# Altium schematic sheet Sch11 - Analog Inputs 1.SchDoc("Sch11 - Analog Inputs 1")
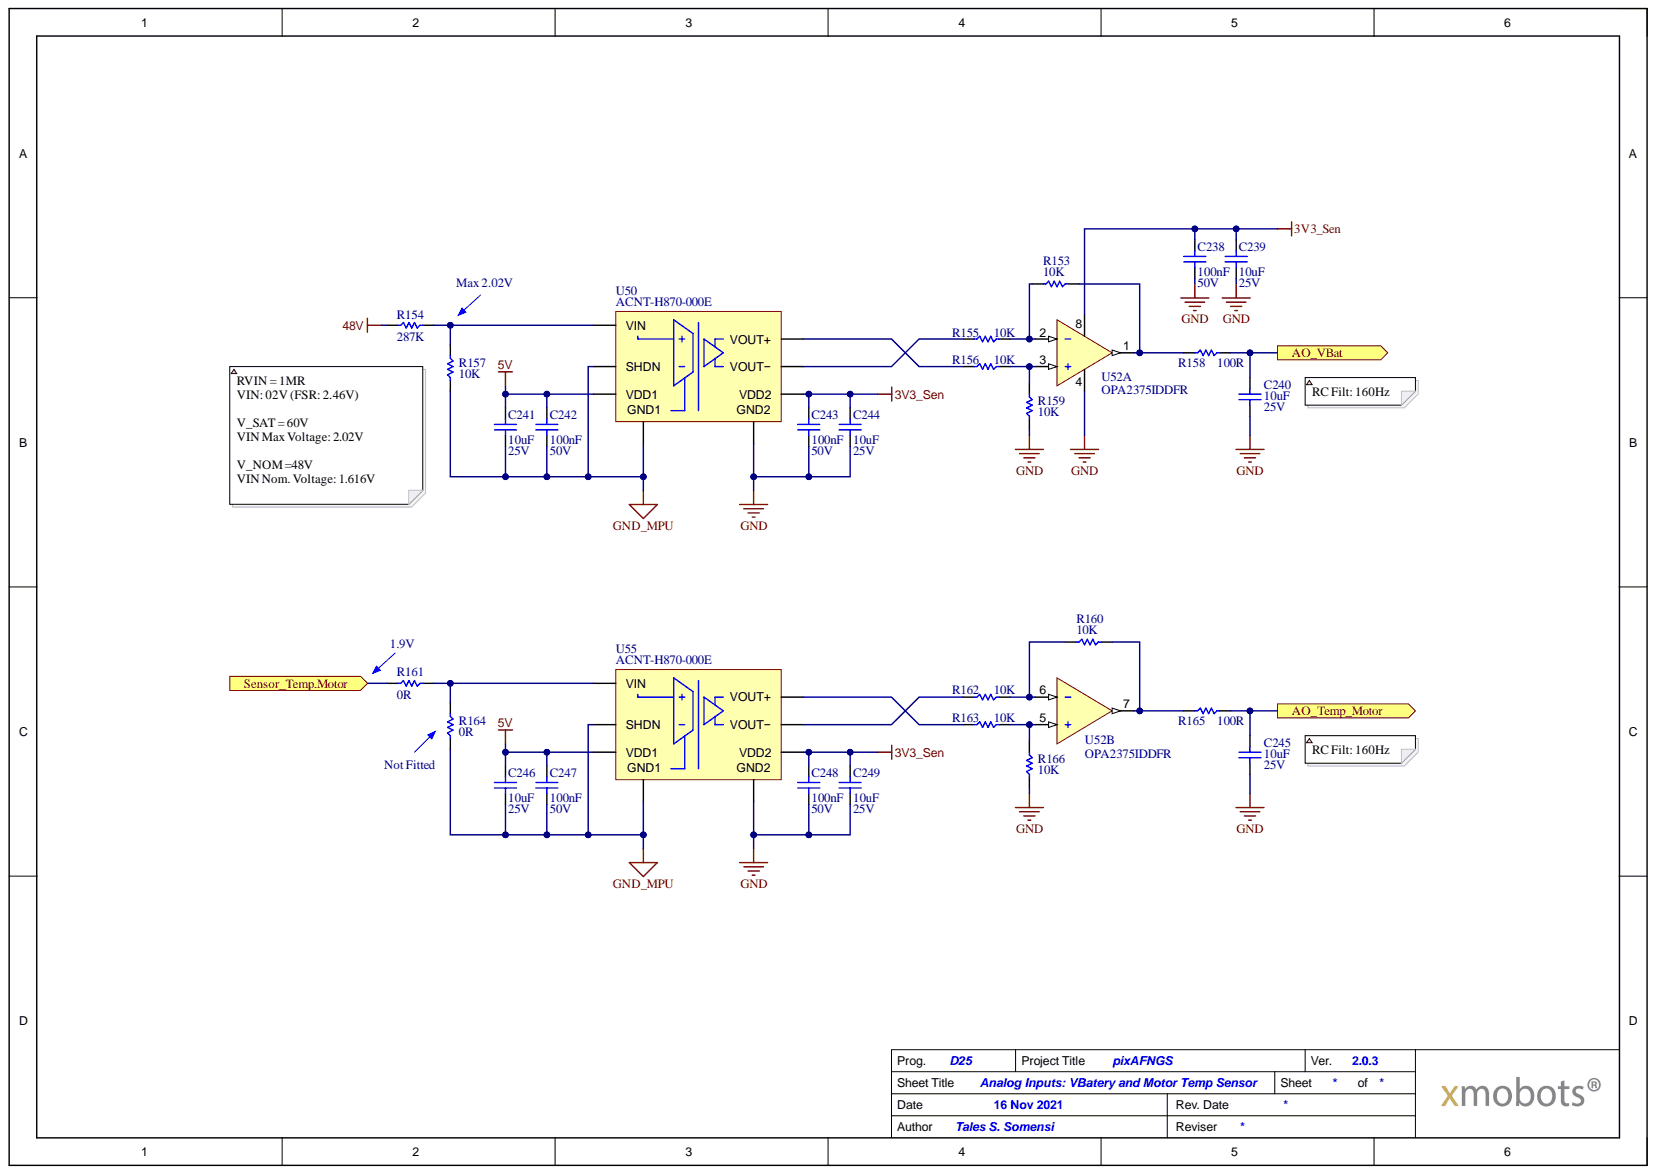
[diagram p0: Sch11 - Analog Inputs 1.SchDoc]

## Parts
C238  Capacitance: 100nF  Voltage: 50V  [0603]  (hidden comment: CL10B104MB8NNNC)
C239  Capacitance: 10uF  Voltage: 25V  [0603]  (hidden comment: GRM188R61E106KA73J)
C240  Capacitance: 10uF  Voltage: 25V  [0603]  (hidden comment: GRM188R61E106KA73J)
C241  Capacitance: 10uF  Voltage: 25V  [0603]  (hidden comment: GRM188R61E106KA73J)
C242  Capacitance: 100nF  Voltage: 50V  [0603]  (hidden comment: CL10B104MB8NNNC)
C243  Capacitance: 100nF  Voltage: 50V  [0603]  (hidden comment: CL10B104MB8NNNC)
C244  Capacitance: 10uF  Voltage: 25V  [0603]  (hidden comment: GRM188R61E106KA73J)
C245  Capacitance: 10uF  Voltage: 25V  [0603]  (hidden comment: GRM188R61E106KA73J)
C246  Capacitance: 10uF  Voltage: 25V  [0603]  (hidden comment: GRM188R61E106KA73J)
C247  Capacitance: 100nF  Voltage: 50V  [0603]  (hidden comment: CL10B104MB8NNNC)
C248  Capacitance: 100nF  Voltage: 50V  [0603]  (hidden comment: CL10B104MB8NNNC)
C249  Capacitance: 10uF  Voltage: 25V  [0603]  (hidden comment: GRM188R61E106KA73J)
R153  Resistance: 10K  [Res_0603]  (hidden comment: RMCF0603FT10K0)
R154  Resistance: 287K  [Res_0603]  (hidden comment: RMCF0603FT287K)
R155  Resistance: 10K  [Res_0603]  (hidden comment: RMCF0603FT10K0)
R156  Resistance: 10K  [Res_0603]  (hidden comment: RMCF0603FT10K0)
R157  Resistance: 10K  [Res_0603]  (hidden comment: RMCF0603FT10K0)
R158  Resistance: 100R  [Res_0603]  (hidden comment: RMCF0603FT100R)
R159  Resistance: 10K  [Res_0603]  (hidden comment: RMCF0603FT10K0)
R160  Resistance: 10K  [Res_0603]  (hidden comment: RMCF0603FT10K0)
R161  Resistance: 0R  [Res_0603]  (hidden comment: RMCF0603ZT0R00)
R162  Resistance: 10K  [Res_0603]  (hidden comment: RMCF0603FT10K0)
R163  Resistance: 10K  [Res_0603]  (hidden comment: RMCF0603FT10K0)
R164  Resistance: 0R  [Res_0603]  (hidden comment: RMCF0603ZT0R00)
R165  Resistance: 100R  [Res_0603]  (hidden comment: RMCF0603FT100R)
R166  Resistance: 10K  [Res_0603]  (hidden comment: RMCF0603FT10K0)
U50  ACNT-H870-000E  [stretched_SO-8]
U52  OPA2375IDDFR  [SOT-23-8]  (parts A,B)
U55  ACNT-H870-000E  [stretched_SO-8]

## Ports
AO_Temp_Motor, AO_VBat, Sensor_Temp.Motor

## Nets
3V3_Sen: C238.2 C239.2 C243.2 C244.2 C248.2 C249.2 U50.8(VDD2) U52.8 U55.8(VDD2)
5V: C241.2 C242.2 C246.2 C247.2 U50.1(VDD1) U55.1(VDD1)
48V: R154.2
GND: C238.1 C239.1 C240.1 C243.1 C244.1 C245.1 C248.1 C249.1 R159.1 R166.1 U50.5 U52.4 U55.5
GND_MPU: C241.1 C242.1 C246.1 C247.1 R157.1 R164.1 U50.3(SHDN) U50.4 U55.3(SHDN) U55.4
AO_Temp_Motor: C245.2 R165.2 ; port AO_Temp_Motor
AO_VBat: C240.2 R158.2 ; port AO_VBat
Sensor_Temp.Motor: R161.1 ; port Sensor_Temp.Motor
NetR153_1: R153.1 R158.1 U52.1
NetR153_2: R153.2 R155.1 U52.2
NetR154_1: R154.1 R157.2 U50.2(VIN)
NetR155_2: R155.2 U50.6(VOUT−)
NetR156_1: R156.1 R159.2 U52.3
NetR156_2: R156.2 U50.7(VOUT+)
NetR160_1: R160.1 R165.1 U52.7
NetR160_2: R160.2 R162.1 U52.6
NetR161_2: R161.2 R164.2 U55.2(VIN)
NetR162_2: R162.2 U55.6(VOUT−)
NetR163_1: R163.1 R166.2 U52.5
NetR163_2: R163.2 U55.7(VOUT+)

## Library data of the parts
C238 C242 C243 C247 C248 = C-100nF-50V-X7R-0603: Capacitor 100nF 50V X7R 0603; Component Kind: Standard; Part Number: CL10B104MB8NNNC; Library Name: xmobots_-_comp_library.IntLib
C239-C241 C244-C246 C249 = C-CER-10uF-25V-X5R-0603: Capacitor 10uF 25V X5R 0603; Component Kind: Standard; Part Number: GRM188R61E106KA73J; Library Name: xmobots_-_comp_library.IntLib
R153 R155-R157 R159 R160 R162 R163 R166 = R-10K-1/10W-1%-0603: Resistor 10k ohms 1/10W 1% 0603; Component Kind: Standard; Part Number: RMCF0603FT10K0; Power: 1/10W; Tolerance: ±1%; Library Name: xmobots_-_comp_library.IntLib
R154 = R-287K-1/10W-1%-0603: Resistor 287k ohms 1/10W 1% 0603; Component Kind: Standard; Library Name: WarLib.IntLib; Part Number: RMCF0603FT287K; Power: 1/10W; Tolerance: ±1%
R158 R165 = R-100R-1/10W-1%-0603: Resistor 100 ohms 1/10W 1% 0603; Component Kind: Standard; Part Number: RMCF0603FT100R; Power: 1/10W; Tolerance: ±1%; Library Name: xmobots_-_comp_library.IntLib
R161 R164 = R-0R-1/10W-1%-0603: Resistor 0 ohm 1/10W 0603; Tolerance: null; Component Kind: Standard; Part Number: RMCF0603ZT0R00; Power: 1/10W; Library Name: xmobots_-_comp_library.IntLib
U52 = U-OP_AMP-OPA2375IDDFR: Operational Amplifier, 10-MHz, Low Broadband Noise, RRO; Component Kind: Standard; Library Name: xmobots_-_comp_library.IntLib
U50 U55 = ACNT-H870-000E: Component Kind: Standard; Library Name: WarLib.IntLib; Part Number: ACNT-H870-000E
